# Altium schematic sheet Can Hub Main.SchDoc
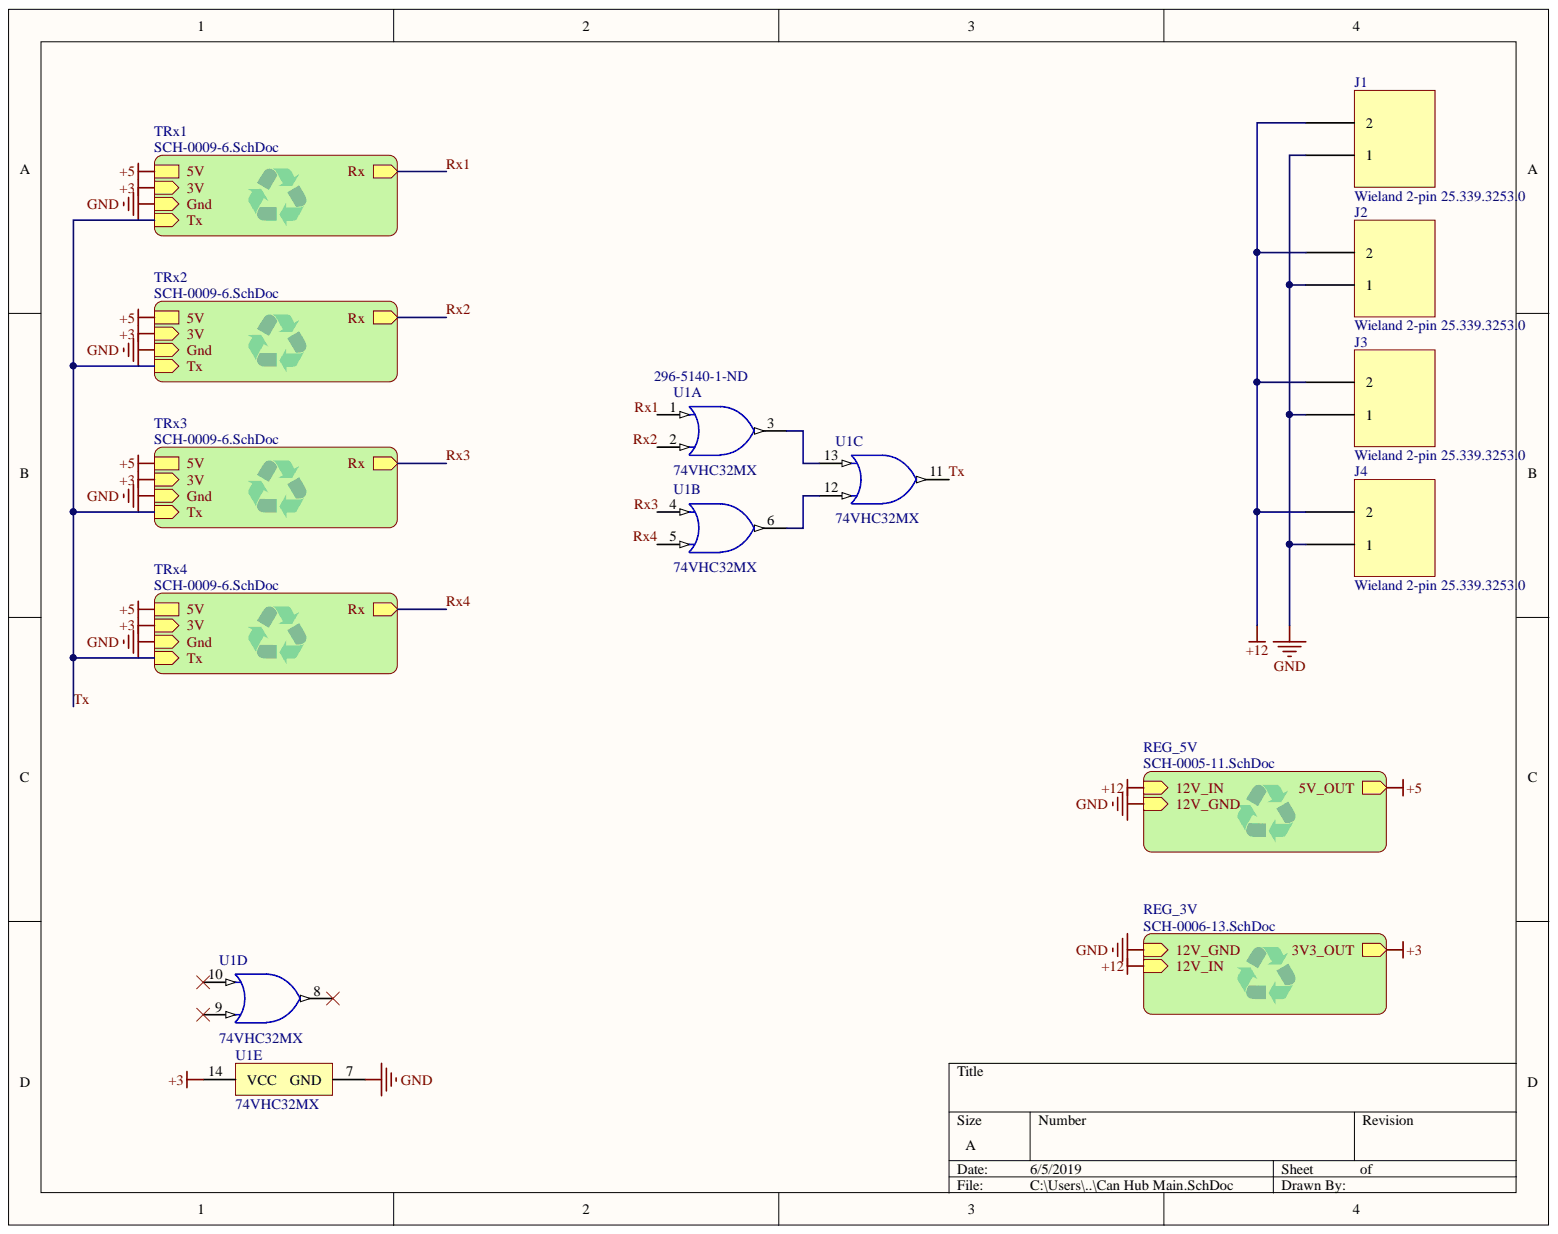
[diagram p0: Can Hub Main.SchDoc]

## Parts
J1  Wieland 2-pin 25.339.3253.0  [Wieland 2-pin 25.339.3253.0-Footprint-1]
J2  Wieland 2-pin 25.339.3253.0  [Wieland 2-pin 25.339.3253.0-Footprint-1]
J3  Wieland 2-pin 25.339.3253.0  [Wieland 2-pin 25.339.3253.0-Footprint-1]
J4  Wieland 2-pin 25.339.3253.0  [Wieland 2-pin 25.339.3253.0-Footprint-1]
U1  74VHC32MX  Supplier Part Number 1: 296-5140-1-ND  [FAIR-M14A_V]  (parts A,B,C,D,E)

## Nets
+3: U1.14(VCC)
+12: J1.2 J2.2 J3.2 J4.2
GND: J1.1 J2.1 J3.1 J4.1 U1.7(GND)
Rx1: U1.1
Rx2: U1.2
Rx3: U1.4
Rx4: U1.5
Tx: U1.11
NetU1_3: U1.3 U1.13
NetU1_6: U1.6 U1.12
NetU1_8: U1.8
NetU1_9: U1.9
NetU1_10: U1.10
+5:

## Library data of the parts
J1-J4 = CMP-003-0005-3: Part ID: 25.339.3253.0; Component Kind: Standard; Library Name: <Company> Vault
U1 = CMP-2000-05690-1: Quad 2-Input OR Gate, 3.8 ns, 2 uA, 2 to 5.5 V, - 40 to 85 degC, 14-Pin SOIC (M14A), RoHS, Tape and Reel; Manufacturer: Fairchild Semiconductor; Current - Output High, Low: 8mA,8mA; Current - Quiescent (Max): 2µA; Dynamic Catalog: 74VHC; Logic Level - High: 1.5V; Logic Level - Low: 0.5V; Logic Type: ORGate; Max Propagation Delay @ V, Max CL: 7.5ns@5V,50pF; Number of Circuits: 4; Number of Inputs: 2; Other Names: 74VHC32MXDKR; Voltage - Supply: 2V~5.5V; Category: IntegratedCircuits(ICs); Component Kind: Standard; Datasheet URL: https://www.fairchildsemi.com/datasheets/74/74VHC32.pdf; Datasheet Version: Rev. 1.3.0, 02/2008; Family: Logic-GatesandInverters; Features: -; Library Name: Altium Content Vault; Manufacturer URL: https://www.fairchildsemi.com/; Mounting Technology: SurfaceMount; Mounting Type: SurfaceMount; Operating Temperature: -40°C~85°C; Package / Case: 14-SOIC(0.154",3.90mmWidth); Package Description: 14-Pin Small Outline Integrated Circuit, Body 8.625 x 3.9 mm, Pitch 1.27 mm; Package Reference: M14A; Package URL: https://www.fairchildsemi.com/package-drawings/M1/M14A.pdf; Packaging: Digi-Reel®; Series: 74VHC; Standard Package: 1; Supplier 1: Digi-Key; Supplier Device Package: 14-SOIC
